# Altium schematic sheet ICM_Sheet1.SchDoc(ICM_Sheet1)
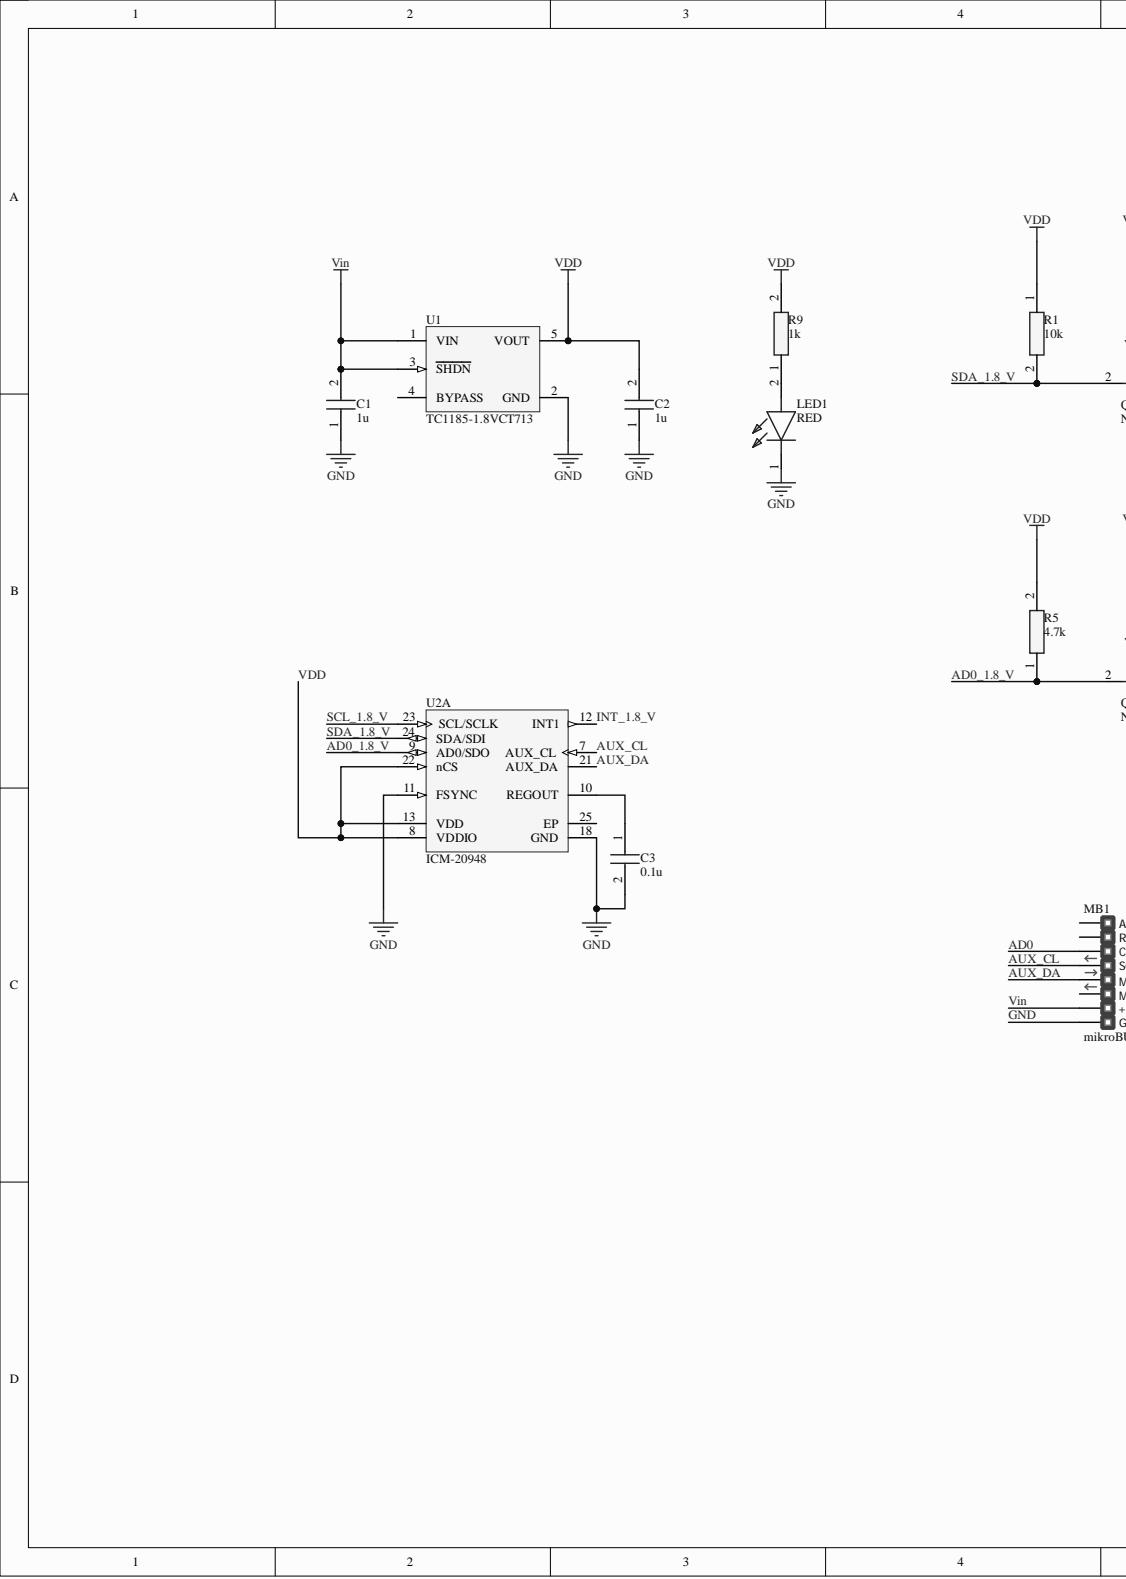
[diagram p0: ICM_Sheet1.SchDoc, left]
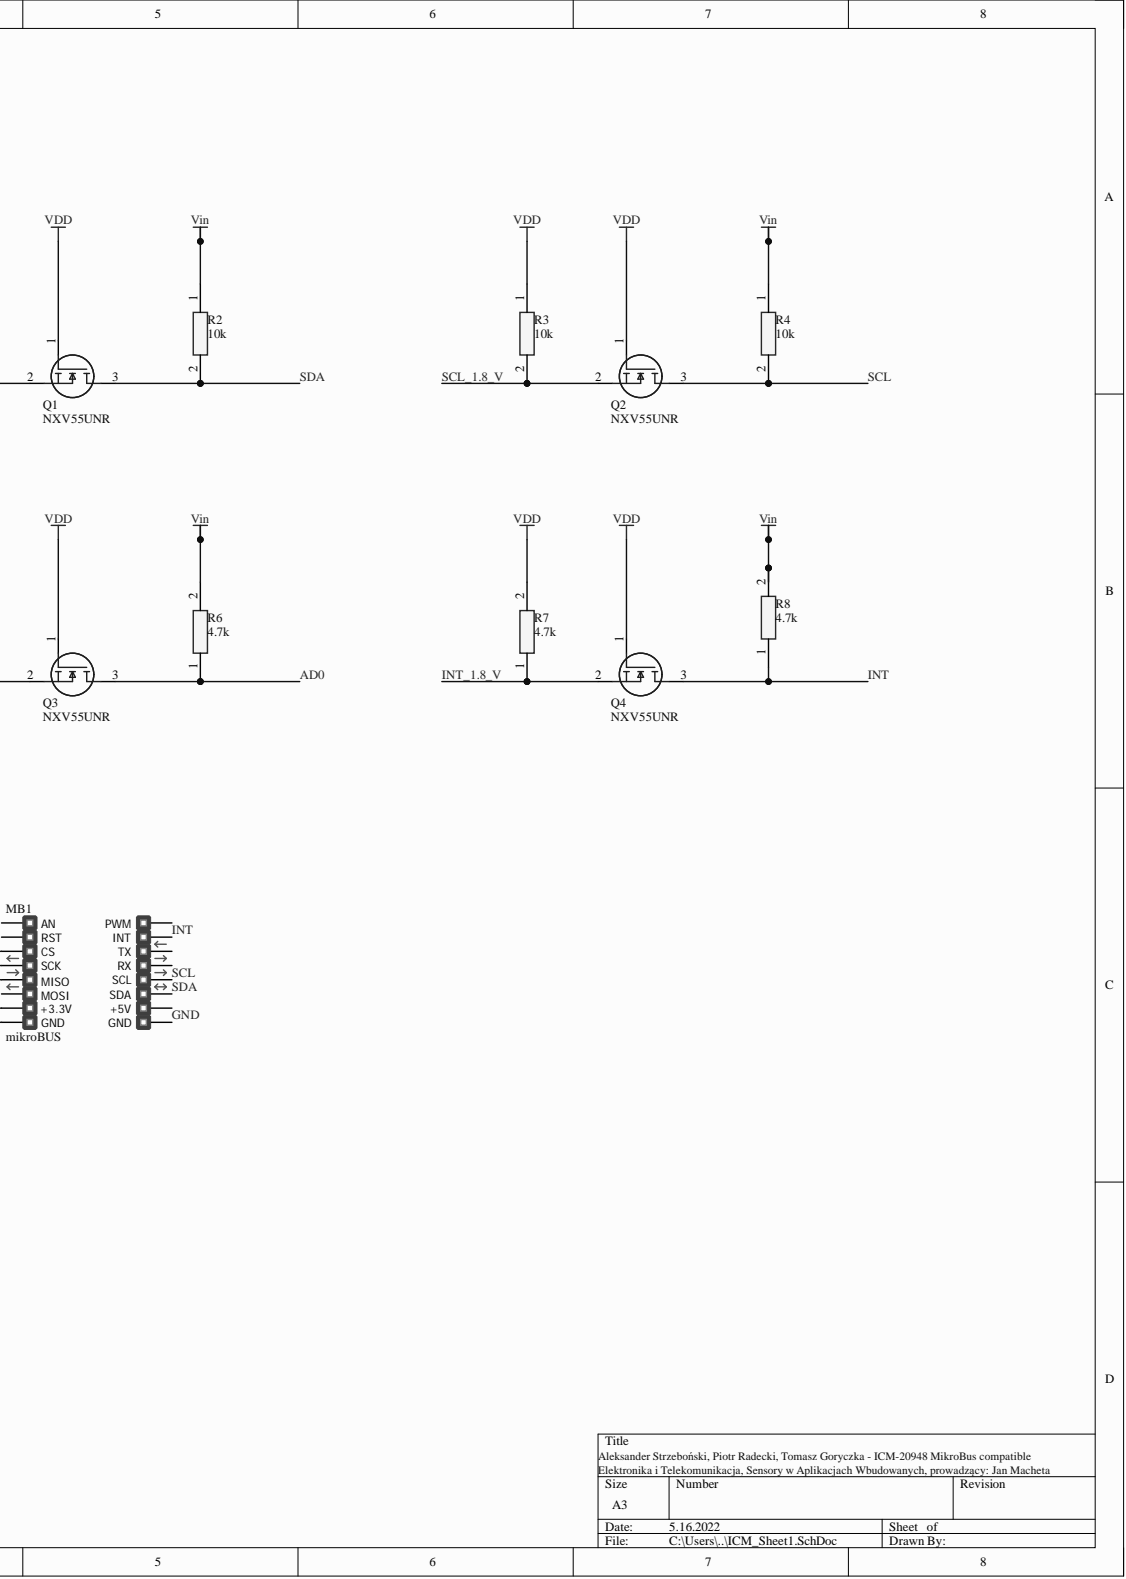
[diagram p1: ICM_Sheet1.SchDoc, right]

## Parts
C1  1u  [CAPC1608X90N]
C2  1u  [CAPC1608X90N]
C3  0.1u  [C0603_Commercial]
LED1  RED  [LEDC1608X60N]
MB1  mikroBUS  [mikroBUS - Mini]  (no BOM)
Q1  NXV55UNR  [SOT95P230X110-3N]
Q2  NXV55UNR  [SOT95P230X110-3N]
Q3  NXV55UNR  [SOT95P230X110-3N]
Q4  NXV55UNR  [SOT95P230X110-3N]
R1  10k  [RESC2012X60N]
R2  10k  [RESC2012X60N]
R3  10k  [RESC2012X60N]
R4  10k  [RESC2012X60N]
R5  4.7k  [RESC2012X50N]
R6  4.7k  [RESC2012X50N]
R7  4.7k  [RESC2012X50N]
R8  4.7k  [RESC2012X50N]
R9  1k  [RESC2012X60N]
U1  TC1185-1.8VCT713  [SOT-23-CT5_N]
U2  ICM-20948  [TDK-QFN-24_L]  (part A)

## Nets
AD0: MB1.3(CS) Q3.3 R6.1
AD0_1.8_V: Q3.2 R5.1 U2.9(AD0/SDO)
AUX_CL: MB1.4(SCK) U2.7(AUX_CL)
AUX_DA: MB1.5(MISO) U2.21(AUX_DA)
GND: C1.1 C2.1 C3.2 LED1.1 MB1.8(GND) MB1.9(GND) U1.2(GND) U2.11(FSYNC) U2.18(GND)
INT: MB1.15(INT) Q4.3 R8.1
INT_1.8_V: Q4.2 R7.1 U2.12(INT1)
SCL: MB1.12(SCL) Q2.3 R4.2
SCL_1.8_V: Q2.2 R3.2 U2.23(SCL/SCLK)
SDA: MB1.11(SDA) Q1.3 R2.2
SDA_1.8_V: Q1.2 R1.2 U2.24(SDA/SDI)
VDD: C2.2 Q1.1 Q2.1 Q3.1 Q4.1 R1.1 R3.1 R5.2 R7.2 R9.2 U1.5(VOUT) U2.8(VDDIO) U2.13(VDD) U2.22(nCS)
Vin: C1.2 MB1.7(+3.3V) R2.1 R4.1 R6.2 R8.2 U1.1(VIN) U1.3(SHDN)
NetC3_1: C3.1 U2.10(REGOUT)
NetLED1_2: LED1.2 R9.1

Not connected: MB1 1(AN) 2(RST) 6(MOSI) 10(+5V) 13(RX) 14(TX) 16(PWM); U1 4(BYPASS); U2 25(EP)

## Library data of the parts
C1 C2 = C0603C105K4RACTU7042: Capacitor; Manufacturer_Name: KEMET; Arrow Part Number: C0603C105K4RACTU7042; Arrow Price/Stock: https://www.arrow.com/en/products/c0603c105k4ractu7042/kemet-corporation; Component Kind: Standard; Datasheet Link: https://www.arrow.com/en/products/c0603c105k4ractu7042/kemet-corporation; Height: 0.9mm; Manufacturer_Part_Number: C0603C105K4RACTU7042
C3 = C0603X5R1E104K030BB: Capacitor; Manufacturer_Name: TDK; Arrow Part Number: C0603X5R1E104K030BB; Arrow Price/Stock: https://www.arrow.com/en/products/c0603x5r1e104k030bb/tdk; Component Kind: Standard; Datasheet Link: https://product.tdk.com/system/files/dam/doc/product/capacitor/ceramic/mlcc/catalog/mlcc_commercial_general_en.pdf; Height: 0.33mm; Manufacturer_Part_Number: C0603X5R1E104K030BB; Mouser Part Number: 810-C0603X5R1E104KBB; Mouser Price/Stock: https://www.mouser.co.uk/ProductDetail/TDK/C0603X5R1E104K030BB?qs=6JAMGB%252BEdkw5r7LrYHn6NA%3D%3D
LED1 = HSMH-C191: LED; Manufacturer_Name: Avago Technologies; Component Kind: Standard; Datasheet Link: https://www.broadcom.com/products/leds-and-displays/chip-leds/0603-1.6-x-0.88mm-top-mount/hsmh-c191#documentation; Height: 0.6mm; Manufacturer_Part_Number: HSMH-C191; Mouser Part Number: 630-HSMH-C191; Mouser Price/Stock: https://www.mouser.co.uk/ProductDetail/Broadcom-Avago/HSMH-C191?qs=YDL0qNrpDT5iSLa%252BxCGQSQ%3D%3D
MB1 = mikroBUS compatible add-on board: Description: mikroBUS compatible add-on board; Library Name: mikroBUS_Add-on_standard.SchLib
Q1-Q4 = NXV55UNR: MOSFET (N-Channel); Manufacturer_Name: Nexperia; Arrow Part Number: NXV55UNR; Arrow Price/Stock: https://www.arrow.com/en/products/nxv55unr/nexperia?region=nac; Component Kind: Standard; Datasheet Link: https://assets.nexperia.com/documents/data-sheet/NXV55UN.pdf; Height: 1.1mm; Manufacturer_Part_Number: NXV55UNR; Mouser Part Number: 771-NXV55UNR; Mouser Price/Stock: https://www.mouser.co.uk/ProductDetail/Nexperia/NXV55UNR?qs=KUoIvG%2F9IlaeWWxKDCJM8Q%3D%3D
R1-R4 = CRCW080510K0JNEAC: Resistor; Manufacturer_Name: Vishay; Arrow Part Number: CRCW080510K0JNEAC; Arrow Price/Stock: https://www.arrow.com/en/products/crcw080510k0jneac/vishay?region=nac; Component Kind: Standard; Datasheet Link: https://componentsearchengine.com/Datasheets/1/CRCW080510K0JNEAC.pdf; Height: 0.6mm; Manufacturer_Part_Number: CRCW080510K0JNEAC; Mouser Part Number: 71-CRCW080510K0JNEAC; Mouser Price/Stock: https://www.mouser.co.uk/ProductDetail/Vishay-Dale/CRCW080510K0JNEAC?qs=E3Y5ESvWgWO0MOXy5ngfMA%3D%3D
R5-R8 = CRCW08054K70JNEB: Resistor; Manufacturer_Name: Vishay; Arrow Part Number: CRCW08054K70JNEB; Arrow Price/Stock: https://www.arrow.com/en/products/crcw08054k70jneb/vishay; Component Kind: Standard; Datasheet Link: http://www.vishay.com/docs/20035/dcrcwe3.pdf; Height: 0.5mm; Manufacturer_Part_Number: CRCW08054K70JNEB; Mouser Part Number: 71-CRCW08054K70JNEB; Mouser Price/Stock: https://www.mouser.co.uk/ProductDetail/Vishay-Dale/CRCW08054K70JNEB?qs=kkyyugUlSrOxPuGatYsUIg%3D%3D
R9 = CRCW08051K00FKEAC: Resistor; Manufacturer_Name: Vishay; Arrow Part Number: CRCW08051K00FKEAC; Arrow Price/Stock: https://www.arrow.com/en/products/crcw08051k00fkeac/vishay?region=nac; Component Kind: Standard; Datasheet Link: https://www.vishay.com/docs/28773/crcwce3.pdf; Height: 0.6mm; Manufacturer_Part_Number: CRCW08051K00FKEAC; Mouser Part Number: 71-CRCW08051K00FKEAC; Mouser Price/Stock: https://www.mouser.co.uk/ProductDetail/Vishay-Dale/CRCW08051K00FKEAC?qs=CZ6xfwOSLTXmQIgaYvN%2FBA%3D%3D
U1 = CMP-0136-00621-1: 50 mA, 100 mA and 150 mA CMOS LDOs with Active-Low Shutdown and Reference Bypass, 5-Pin SOT-23, Extended Temperature, Tape and Reel; Code_IPC: SOT23-5; Component Kind: Standard; DatasheetVersion: revE, Feb-2007; Library Name: Altium Content Vault; PackageDescription: 5-Lead Plastic Small Outline Transistor (CT) [SOT-23]; PackageReference: SOT-23-CT5; PackageVersion: revBB, Aug-2009
U2 = CMP-2000-07532-1: World’s Lowest Power 9-Axis MEMS MotionTracking(TM) Device, 1.71 to 3.6 V, -40 to 85 degC, 24-Pin QFN, RoHS, Tape and Reel; Manufacturer: TDK; Component Kind: Standard; Datasheet URL: https://www.invensense.com/wp-content/uploads/2016/06/DS-000189-ICM-20948-v1.3.pdf; Datasheet Version: Rev. 1.3, 06/2017; Library Name: Altium Content Vault; Manufacturer URL: http://www.tdk.com/; Mounting Technology: SurfaceMount; Package Description: 24-Pin Quad Flat No-lead Package, Body 3 x 3 mm, Pitch 0.4 mm; Package Reference: QFN-24
